# Altium schematic sheet Alarms and Buttons.SchDoc("Alarms and Buttons")
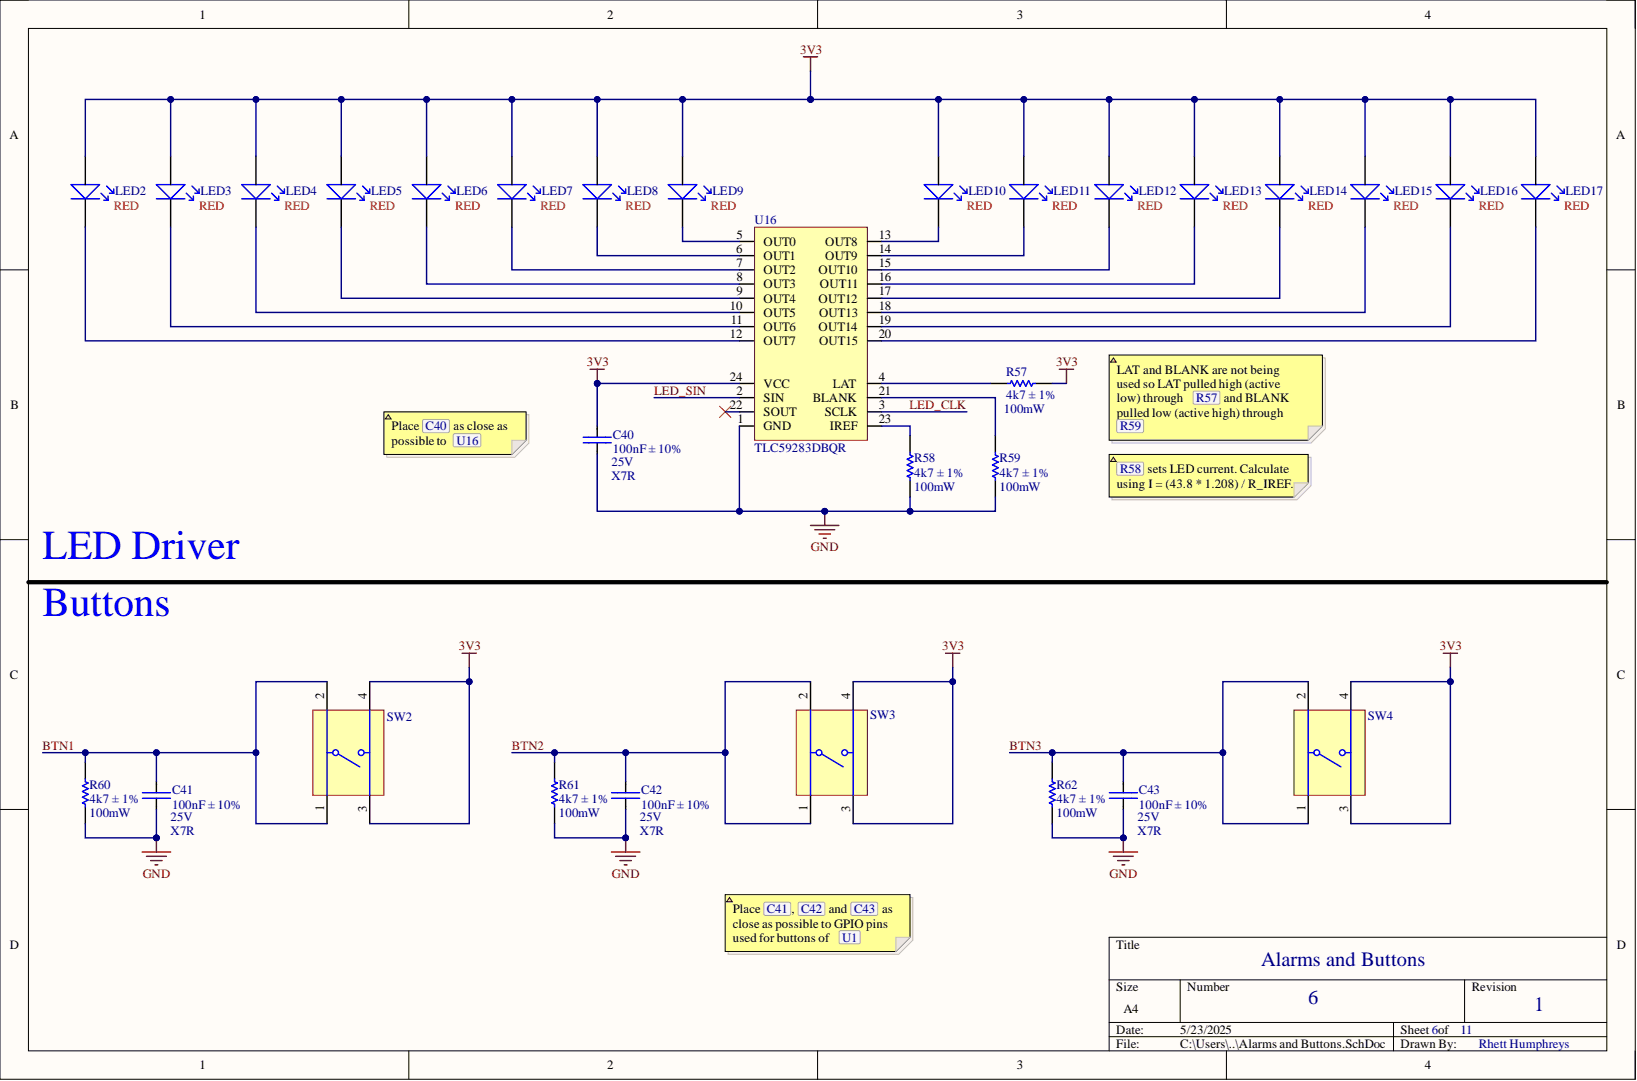
[diagram p0: Alarms and Buttons.SchDoc]

## Parts
C40  100nF ± 10%  [CAP-0603]
C41  100nF ± 10%  [CAP-0603]
C42  100nF ± 10%  [CAP-0603]
C43  100nF ± 10%  [CAP-0603]
LED2  (no value)  [0603 LED]
LED3  (no value)  [0603 LED]
LED4  (no value)  [0603 LED]
LED5  (no value)  [0603 LED]
LED6  (no value)  [0603 LED]
LED7  (no value)  [0603 LED]
LED8  (no value)  [0603 LED]
LED9  (no value)  [0603 LED]
LED10  (no value)  [0603 LED]
LED11  (no value)  [0603 LED]
LED12  (no value)  [0603 LED]
LED13  (no value)  [0603 LED]
LED14  (no value)  [0603 LED]
LED15  (no value)  [0603 LED]
LED16  (no value)  [0603 LED]
LED17  (no value)  [0603 LED]
R57  4k7 ± 1%  [RES-0603]
R58  4k7 ± 1%  [RES-0603]
R59  4k7 ± 1%  [RES-0603]
R60  4k7 ± 1%  [RES-0603]
R61  4k7 ± 1%  [RES-0603]
R62  4k7 ± 1%  [RES-0603]
SW2  FSM4JSMATR  [TECO-FSM4JSMATR_V]  (value not drawn)
SW3  FSM4JSMATR  [TECO-FSM4JSMATR_V]  (value not drawn)
SW4  FSM4JSMATR  [TECO-FSM4JSMATR_V]  (value not drawn)
U16  TLC59283DBQR  [SOP64P600X175-24N]

## Nets
3V3: C40.2 LED2.1 LED3.1 LED4.1 LED5.1 LED6.1 LED7.1 LED8.1 LED9.1 LED10.1 LED11.1 LED12.1 LED13.1 LED14.1 LED15.1 LED16.1 LED17.1 R57.2 SW2.3 SW2.4 SW3.3 SW3.4 SW4.3 SW4.4 U16.24(VCC)
GND: C40.1 C41.1 C42.1 C43.1 R58.1 R59.1 R60.2 R61.2 R62.2 U16.1(GND)
BTN1: C41.2 R60.1 SW2.1 SW2.2
BTN2: C42.2 R61.1 SW3.1 SW3.2
BTN3: C43.2 R62.1 SW4.1 SW4.2
LED_CLK: U16.3(SCLK)
LED_SIN: U16.2(SIN)
NetLED2_2: LED2.2 U16.12(OUT7)
NetLED3_2: LED3.2 U16.11(OUT6)
NetLED4_2: LED4.2 U16.10(OUT5)
NetLED5_2: LED5.2 U16.9(OUT4)
NetLED6_2: LED6.2 U16.8(OUT3)
NetLED7_2: LED7.2 U16.7(OUT2)
NetLED8_2: LED8.2 U16.6(OUT1)
NetLED9_2: LED9.2 U16.5(OUT0)
NetLED10_2: LED10.2 U16.13(OUT8)
NetLED11_2: LED11.2 U16.14(OUT9)
NetLED12_2: LED12.2 U16.15(OUT10)
NetLED13_2: LED13.2 U16.16(OUT11)
NetLED14_2: LED14.2 U16.17(OUT12)
NetLED15_2: LED15.2 U16.18(OUT13)
NetLED16_2: LED16.2 U16.19(OUT14)
NetLED17_2: LED17.2 U16.20(OUT15)
NetR57_1: R57.1 U16.4(LAT)
NetR58_2: R58.2 U16.23(IREF)
NetR59_2: R59.2 U16.21(BLANK)

Not connected: U16 22(SOUT)

## Library data of the parts
C40-C43 = CAP-0603: Capacitor chip unpolarized. 0603; Component Kind: Standard; Library Name: Master.SchLib
LED2-LED17 = 0603 LED: Component Kind: Standard; Library Name: Master.SchLib
R57-R62 = RES-0603: Resistor chip. 0603; Component Kind: Standard; Library Name: Master.SchLib
SW2-SW4 = CMP-2000-07486-1: Micro Miniature Push Button Switch FSM JSM, 50 mA, -35 to 85 degC, 4-Pin SMD, RoHS, Tape and Reel; Manufacturer: TE Connectivity; Actuator Length: 0.055inch; Actuator Material: Polyamide; Capacitance: 0f; Case\/Package: SMD/SMT; Contact Current Rating: 50mA; Contact Resistance: 100mOhm; Electrical Life: 100000Cycles; Illumination Type: Illuminated; Max Voltage Rating (DC): 12V; Operating Force: 160gf; Switch Travel: 0.25mm; Throw Configuration: SPST; Voltage Rating (DC): 24V; Component Kind: Standard; Contact Material: CopperAlloy; Contact Plating: Silver; Current Rating: 50mA; Datasheet URL: http://www.te.com/commerce/DocumentDelivery/DDEController?Action=showdoc&DocId=Customer+Drawing%7F2-1437565-7%7FV%7Fpdf%7FEnglish%7FENG_CD_2-1437565-7_V.pdf%7F4-1437565-2; Datasheet Version: Rev. V, 02/2015; Library Name: Altium Content Vault; Manufacturer URL: http://www.te.com/; Max Operating Temperature: 85degC; Mechanical Life: 100000Cycles; Min Operating Temperature: -35degC; Mount: SurfaceMount; Mounting Technology: SurfaceMount; Orientation: Straight; Package Description: 4-Pin Surface Mount Device, Body 6 x 6 mm, Pitch 4.5 mm; Package Quantity: 1200; Package Reference: FSM4JSMATR; Packaging: TapeandReel; REACH SVHC: Unknown; RoHSCompliant: true; Termination: GullWing
U16 = TLC59283DBQR: LED Driver Const Current 16 Output; Component Kind: Standard; Library Name: Master.SchLib
